# Altium schematic sheet LCD.SchDoc
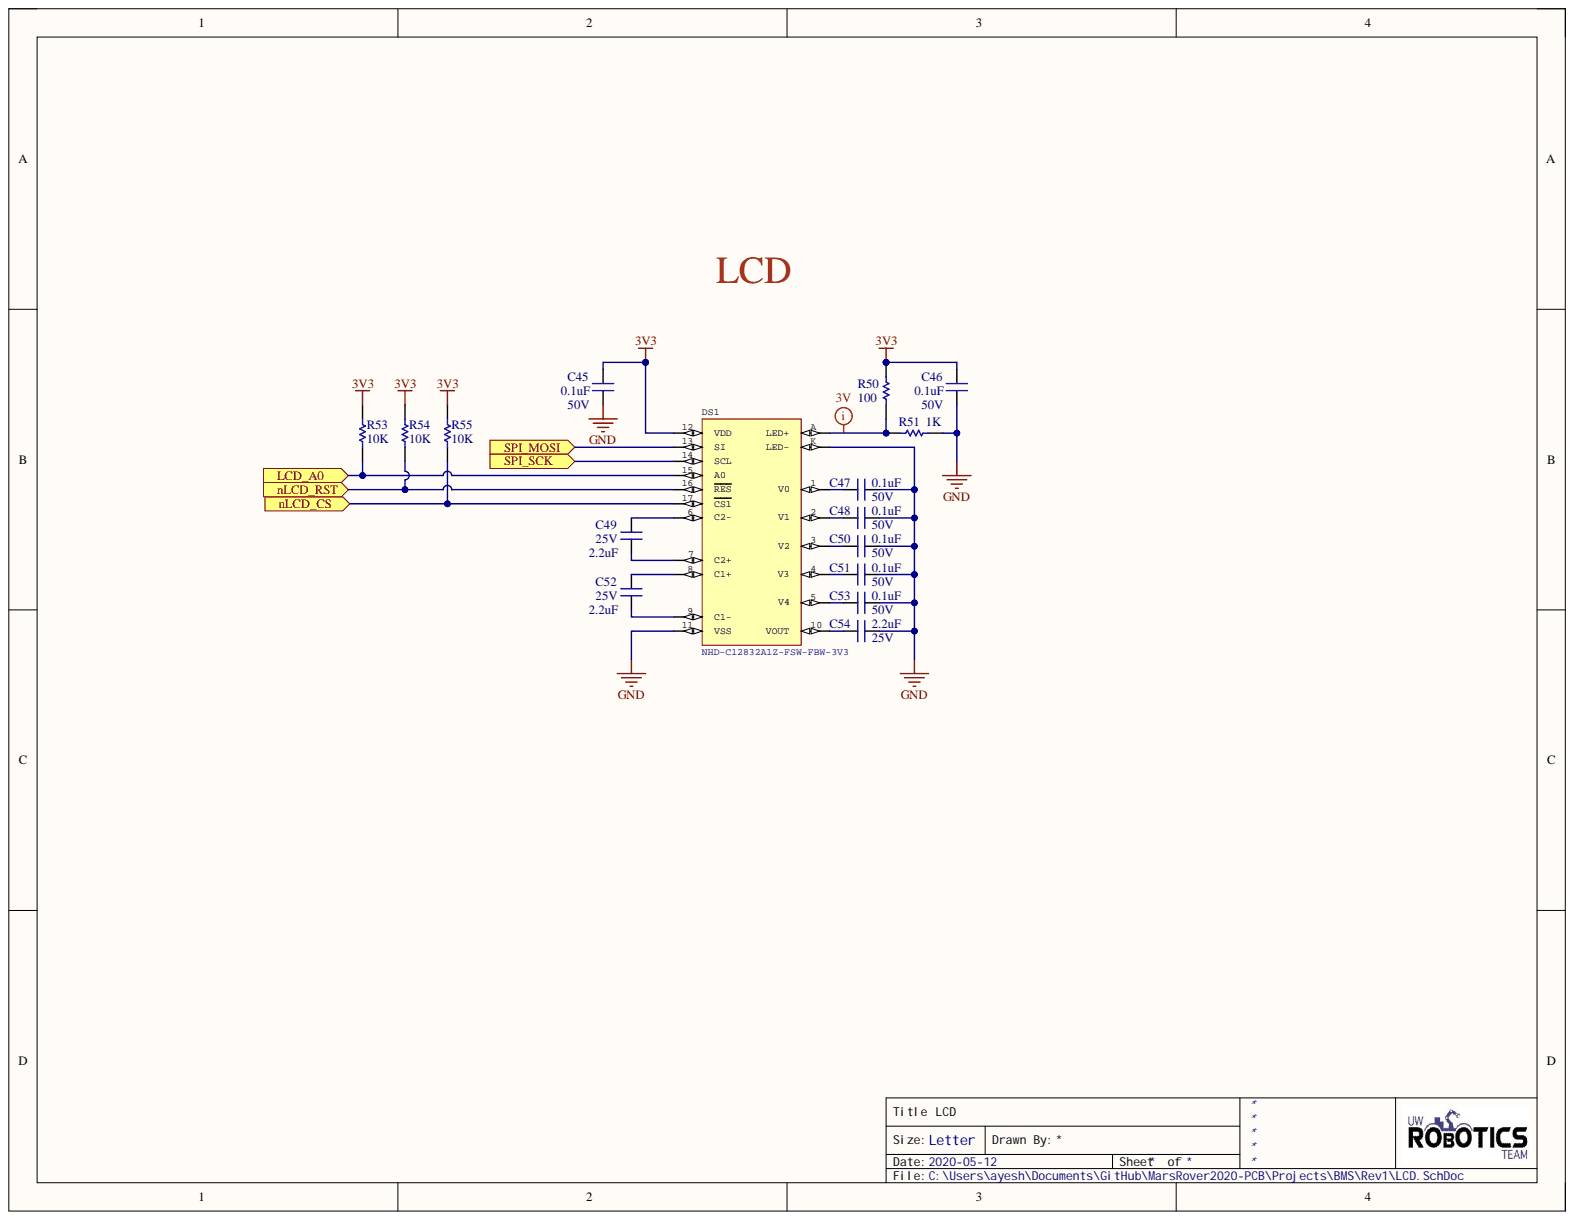
[diagram p0: LCD.SchDoc]

## Parts
C45  value 0.1uF  Voltage Rating: 50V  [CAPC2012X140N]  (hidden comment: CAP_0.1uF_50V_0805)
C46  value 0.1uF  Voltage Rating: 50V  [CAPC2012X140N]  (hidden comment: CAP_0.1uF_50V_0805)
C47  value 0.1uF  Voltage Rating: 50V  [CAPC2012X140N]  (hidden comment: CAP_0.1uF_50V_0805)
C48  value 0.1uF  Voltage Rating: 50V  [CAPC2012X140N]  (hidden comment: CAP_0.1uF_50V_0805)
C49  value 2.2uF  Voltage Rating: 25V  [CAPC2012X140N]  (hidden comment: CAP_2.2uF_25V_0805)
C50  value 0.1uF  Voltage Rating: 50V  [CAPC2012X140N]  (hidden comment: CAP_0.1uF_50V_0805)
C51  value 0.1uF  Voltage Rating: 50V  [CAPC2012X140N]  (hidden comment: CAP_0.1uF_50V_0805)
C52  value 2.2uF  Voltage Rating: 25V  [CAPC2012X140N]  (hidden comment: CAP_2.2uF_25V_0805)
C53  value 0.1uF  Voltage Rating: 50V  [CAPC2012X140N]  (hidden comment: CAP_0.1uF_50V_0805)
C54  value 2.2uF  Voltage Rating: 25V  [CAPC2012X140N]  (hidden comment: CAP_2.2uF_25V_0805)
DS1  NHD-C12832A1Z-FSW-FBW-3V3  [NHDC12832A1ZFSWFBW3V3]
R50  value 100  [RESC2012X60N]  (hidden comment: RES_100R_0805)
R51  value 1K  Resistance: 1K  [RESC2012X60N]  (hidden comment: RES_1K_0805)
R53  value 10K  Resistance: 10K  [RESC2012X60N]  (hidden comment: RES_10K_0805)
R54  value 10K  Resistance: 10K  [RESC2012X60N]  (hidden comment: RES_10K_0805)
R55  value 10K  Resistance: 10K  [RESC2012X60N]  (hidden comment: RES_10K_0805)

## Ports
LCD_A0, nLCD_CS, nLCD_RST, SPI_MOSI, SPI_SCK

## Nets
3V3: C45.1 C46.1 DS1.12(VDD) R50.2 R53.2 R54.2 R55.2
GND: C45.2 C46.2 C47.1 C48.1 C50.1 C51.1 C53.1 C54.1 DS1.11(VSS) DS1.K(LED-) R51.2
LCD_A0: DS1.15(A0) R53.1 ; port LCD_A0
nLCD_CS: DS1.17(CS1) R55.1 ; port nLCD_CS
nLCD_RST: DS1.16(RES) R54.1 ; port nLCD_RST
SPI_MOSI: DS1.13(SI) ; port SPI_MOSI
SPI_SCK: DS1.14(SCL) ; port SPI_SCK
NetC47_2: C47.2 DS1.1(V0)
NetC48_2: C48.2 DS1.2(V1)
NetC49_1: C49.1 DS1.7(C2+)
NetC49_2: C49.2 DS1.6(C2-)
NetC50_2: C50.2 DS1.3(V2)
NetC51_2: C51.2 DS1.4(V3)
NetC52_1: C52.1 DS1.9(C1-)
NetC52_2: C52.2 DS1.8(C1+)
NetC53_2: C53.2 DS1.5(V4)
NetC54_2: C54.2 DS1.10(VOUT)
NetDS1_A: DS1.A(LED+) R50.1 R51.1

## Library data of the parts
C45-C48 C50 C51 C53 = CAP_0.1uF_50V_0805: CAP CER 0.1UF 50V X7R 0805; Manufacturer 1: Murata Electronics; Manufacturer Part Number 1: GCD21BR71H104KA01L; Capacitance: 100nF; Tolerance: 10%; Case Code (Imperial): 0805; Case Code (Metric): 2012; Case/Package: 0805; Component Kind: Standard; ComponentLink1Description: https://datasheet.ciiva.com/29537/c03e-29537701.pdf?src-supplier=Digi-Key; ComponentLink1URL: https://datasheet.ciiva.com/29537/c03e-29537701.pdf?src-supplier=Digi-Key; Depth: 1.25mm; Dielectric: X7R; Height: 1.25mm; Length: 2mm; Max Operating Temperature: 125°C; Min Operating Temperature: -55°C; Mount: Surface Mount; Ratings: AEC-Q200; Supplier 1: Digi-Key; Supplier Part Number 1: 490-11955-1-ND; Termination: SMD/SMT; Thickness: 0.049inch; Width: 0.049inch; Library Name: UWRT_Schematic_Library_2020.SchLib
C49 C52 C54 = CAP_2.2uF_25V_0805: CAP CER 2.2UF 25V X7R 0805; Manufacturer 1: Murata Electronics; Manufacturer Part Number 1: GRM21BR71E225KA73L; Capacitance: 2200nF; Tolerance: 10%; Case Code (Imperial): 0805; Case Code (Metric): 2012; Case/Package: 0805; Component Kind: Standard; Depth: 1.25mm; Dielectric: X7R; Height: 1.25mm; Length: 2mm; Max Operating Temperature: 125°C; Min Operating Temperature: -55°C; Mount: Surface Mount; Ratings: AEC-Q200; Supplier 1: Digi-Key; Supplier Part Number 1: 490-3331-2-ND; Termination: SMD/SMT; Thickness: 0.049inch; Width: 0.049inch; Library Name: UWRT_Schematic_Library_2020.SchLib
DS1 = NHD-C12832A1Z-FSW-FBW-3V3: LCD COG GRAPHIC 128X32; Manufacturer 1: Newhaven Display Intl; Component Kind: Standard; Manufacturer Part Number 1: NHD-C12832A1Z-FSW-FBW-3V3; Supplier 1: Digi-Key; Supplier Part Number 1: NHD-C12832A1Z-FSW-FBW-3V3-ND; Library Name: UWRT_Schematic_Library_2020.SchLib
R50 = RES_100R_0805: RES SMD 100 OHM 1% 1/8W 0805; Manufacturer 1: Yageo; Manufacturer Part Number 1: RC0805FR-07100RL; Power Rating: 125mW; Case Code (Imperial): 0805; Case Code (Metric): 2012; Component Kind: Standard; Mount: Surface Mount; Supplier 1: Digi-Key; Supplier Part Number 1: 311-100CRCT-ND; Library Name: UWRT_Schematic_Library_2020.SchLib
R51 = RES_1K_0805: RES SMD 1K OHM 1% 1/8W 0805; Manufacturer 1: Yageo; Manufacturer Part Number 1: RC0805FR-071KL; Power Rating: 125mW; Case Code (Imperial): 0805; Case Code (Metric): 2012; Component Kind: Standard; Max Operating Temperature: 155°C; Min Operating Temperature: -55°C; Supplier 1: Digi-Key; Supplier Part Number 1: 311-1.00KCRCT-ND; Termination: SMD/SMT; Tolerance: 1%; Library Name: UWRT_Schematic_Library_2020.SchLib
R53-R55 = RES_10K_0805: RES SMD 10K OHM 1% 1/8W 0805; Manufacturer 1: Yageo; Manufacturer Part Number 1: RC0805FR-0710KL; Power Rating: 125mW; Case Code (Imperial): 0805; Case Code (Metric): 2012; Component Kind: Standard; Max Operating Temperature: 155°C; Min Operating Temperature: -55°C; Supplier 1: Digi-Key; Supplier Part Number 1: 311-10.0KCRCT-ND; Termination: SMD/SMT; Tolerance: 1%; Library Name: UWRT_Schematic_Library_2020.SchLib
